# Altium schematic sheet 03-Microprocessor.SchDoc(Microprocessor)
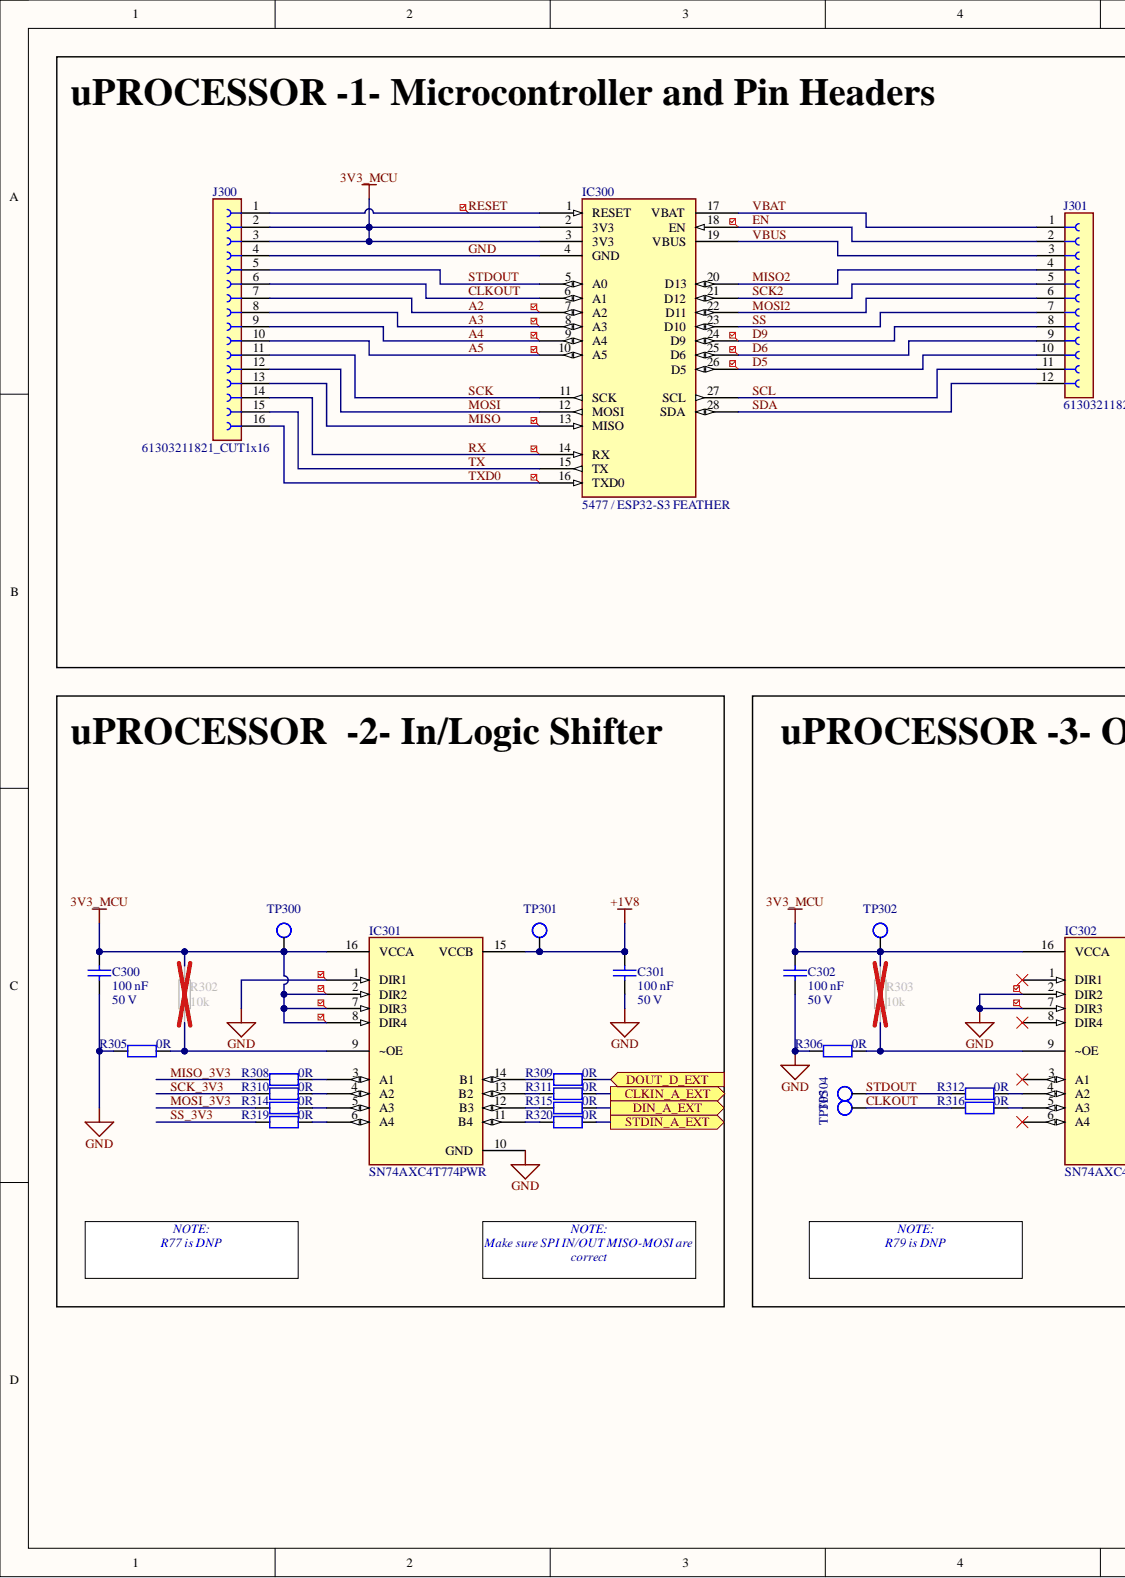
[diagram p0: 03-Microprocessor.SchDoc, left]
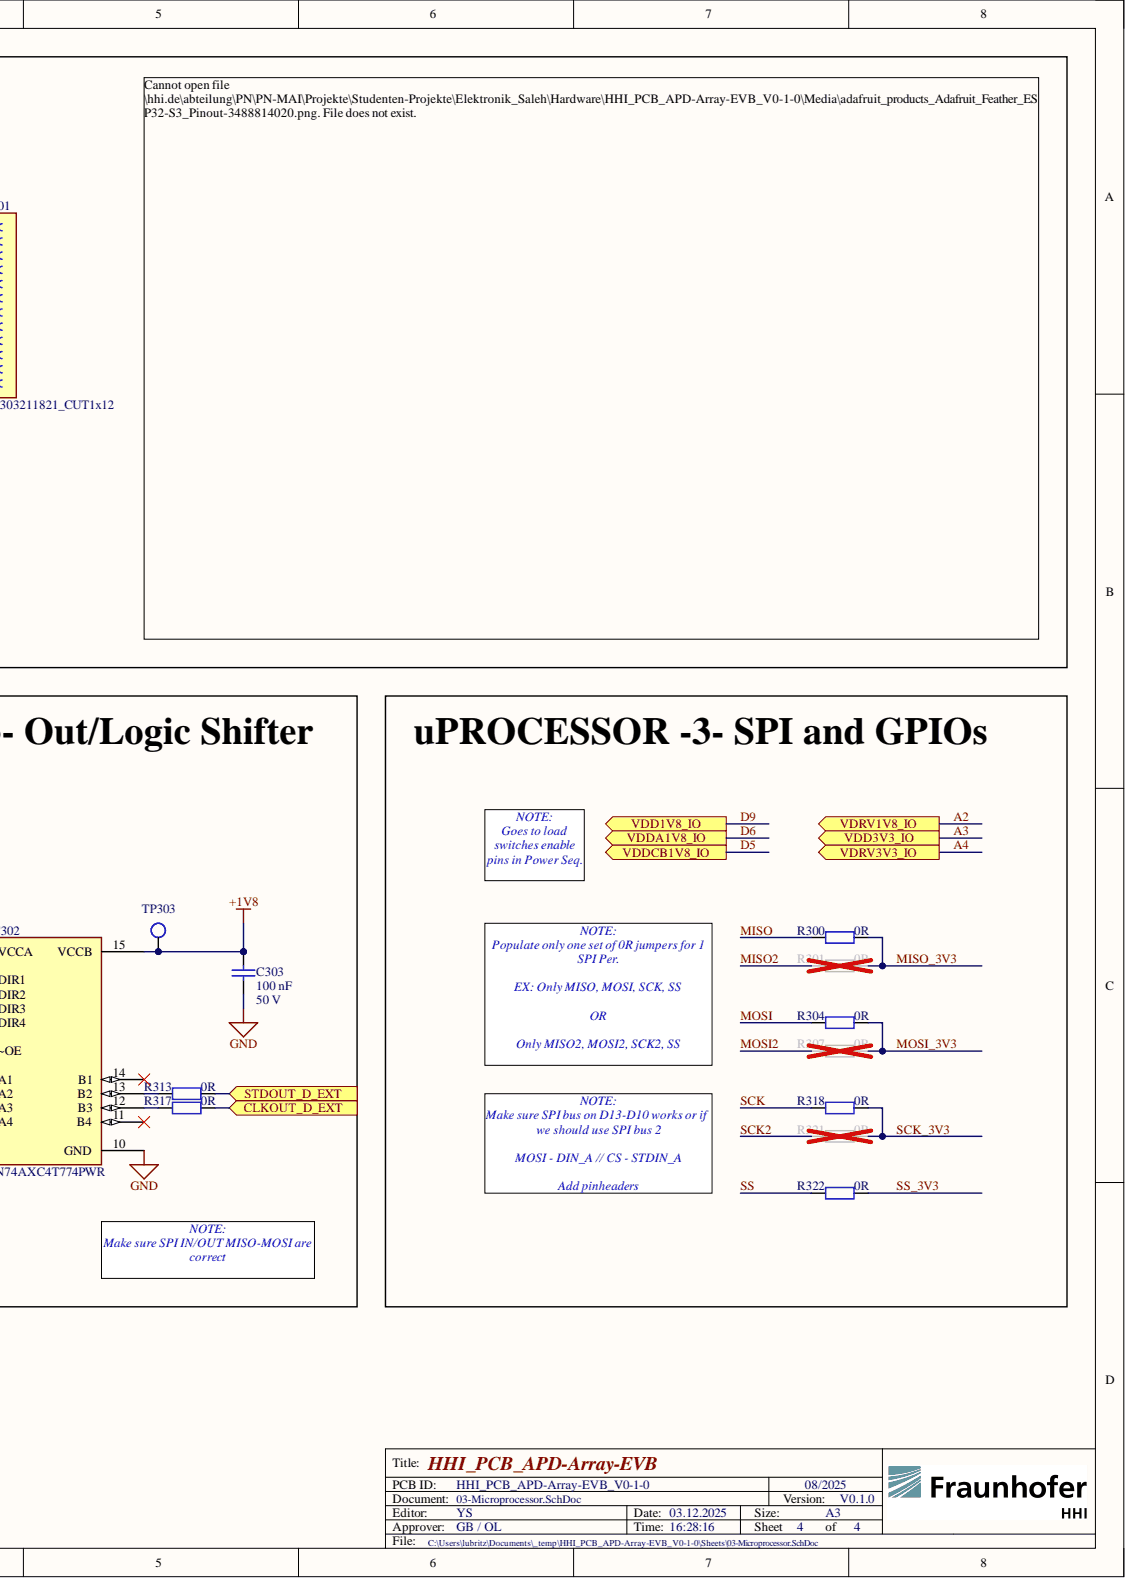
[diagram p1: 03-Microprocessor.SchDoc, right]

## Parts
C300  100 nF  Voltage: 50 V  [CAP_CAPC1608_0603_N]
C301  100 nF  Voltage: 50 V  [CAP_CAPC1608_0603_N]
C302  100 nF  Voltage: 50 V  [CAP_CAPC1608_0603_N]
C303  100 nF  Voltage: 50 V  [CAP_CAPC1608_0603_N]
IC300  5477 / ESP32-S3 FEATHER  MfrNo: 5477  [IC_ESP32-S3-FEATHER]
IC301  SN74AXC4T774PWR  [IC_TSSOP-16_TSOP65P640X120-16N]
IC302  SN74AXC4T774PWR  [IC_TSSOP-16_TSOP65P640X120-16N]
J300  61303211821_CUT1x16  [CON_FEMALE_61303211821_CUT1x16]
J301  61303211821_CUT1x12  [CON_FEMALE_61303211821_CUT1x12]
R300  0R  [RES_RESC1608_0603_N]
R301  0R  [RES_RESC1608_0603_N]
R302  10k  [RES_RESC1608_0603_N]
R303  10k  [RES_RESC1608_0603_N]
R304  0R  [RES_RESC1608_0603_N]
R305  0R  [RES_RESC1608_0603_N]
R306  0R  [RES_RESC1608_0603_N]
R307  0R  [RES_RESC1608_0603_N]
R308  0R  [RES_RESC1608_0603_N]
R309  0R  [RES_RESC1608_0603_N]
R310  0R  [RES_RESC1608_0603_N]
R311  0R  [RES_RESC1608_0603_N]
R312  0R  [RES_RESC1608_0603_N]
R313  0R  [RES_RESC1608_0603_N]
R314  0R  [RES_RESC1608_0603_N]
R315  0R  [RES_RESC1608_0603_N]
R316  0R  [RES_RESC1608_0603_N]
R317  0R  [RES_RESC1608_0603_N]
R318  0R  [RES_RESC1608_0603_N]
R319  0R  [RES_RESC1608_0603_N]
R320  0R  [RES_RESC1608_0603_N]
R321  0R  [RES_RESC1608_0603_N]
R322  0R  [RES_RESC1608_0603_N]
TP300  0,8 mm / 1,4 mm  [MISC_TP_THT_RD_0,8MM_1,4MM]  (value not drawn)  (no BOM)
TP301  0,8 mm / 1,4 mm  [MISC_TP_THT_RD_0,8MM_1,4MM]  (value not drawn)  (no BOM)
TP302  0,8 mm / 1,4 mm  [MISC_TP_THT_RD_0,8MM_1,4MM]  (value not drawn)  (no BOM)
TP303  0,8 mm / 1,4 mm  [MISC_TP_THT_RD_0,8MM_1,4MM]  (value not drawn)  (no BOM)
TP304  0,8 mm / 1,4 mm  [MISC_TP_THT_RD_0,8MM_1,4MM]  (value not drawn)  (no BOM)
TP305  0,8 mm / 1,4 mm  [MISC_TP_THT_RD_0,8MM_1,4MM]  (value not drawn)  (no BOM)

## Ports
CLKIN_A_EXT, CLKOUT_D_EXT, DIN_A_EXT, DOUT_D_EXT, STDIN_A_EXT, STDOUT_D_EXT, VDD1V8_IO, VDD3V3_IO, VDDA1V8_IO, VDDCB1V8_IO, VDRV1V8_IO, VDRV3V3_IO

## Nets
3V3_MCU: C300.1 C302.1 IC300.2(3V3) IC300.3(3V3) IC301.2(DIR2) IC301.7(DIR3) IC301.8(DIR4) IC301.16(VCCA) IC302.16(VCCA) J300.2 J300.3 R302.2 R303.2 TP300.1 TP302.1
+1V8: C301.1 C303.1 IC301.15(VCCB) IC302.15(VCCB) TP301.1 TP303.1
A2: IC300.7(A2) J300.7 ; port VDRV1V8_IO
A3: IC300.8(A3) J300.8 ; port VDD3V3_IO
A4: IC300.9(A4) J300.9 ; port VDRV3V3_IO
A5: IC300.10(A5) J300.10
CLKIN_A_EXT: R311.2 ; port CLKIN_A_EXT
CLKOUT: IC300.6(A1) J300.6 R316.1 TP305.1
CLKOUT_D_EXT: R317.2 ; port CLKOUT_D_EXT
D5: IC300.26(D5) J301.10 ; port VDDCB1V8_IO
D6: IC300.25(D6) J301.9 ; port VDDA1V8_IO
D9: IC300.24(D9) J301.8 ; port VDD1V8_IO
DIN_A_EXT: R315.2 ; port DIN_A_EXT
DOUT_D_EXT: R309.2 ; port DOUT_D_EXT
EN: IC300.18(EN) J301.2
GND: C300.2 C301.2 C302.2 C303.2 IC300.4(GND) IC301.1(DIR1) IC301.10(GND) IC302.2(DIR2) IC302.7(DIR3) IC302.10(GND) J300.4 R305.1 R306.1
MISO: IC300.13(MISO) J300.13 R300.1
MISO2: IC300.20(D13) J301.4 R301.1
MISO_3V3: R300.2 R301.2 R308.1
MOSI: IC300.12(MOSI) J300.12 R304.1
MOSI2: IC300.22(D11) J301.6 R307.1
MOSI_3V3: R304.2 R307.2 R314.1
RESET: IC300.1(RESET) J300.1
RX: IC300.14(RX) J300.14
SCK: IC300.11(SCK) J300.11 R318.1
SCK2: IC300.21(D12) J301.5 R321.1
SCK_3V3: R310.1 R318.2 R321.2
SCL: IC300.27(SCL) J301.11
SDA: IC300.28(SDA) J301.12
SS: IC300.23(D10) J301.7 R322.1
SS_3V3: R319.1 R322.2
STDIN_A_EXT: R320.2 ; port STDIN_A_EXT
STDOUT: IC300.5(A0) J300.5 R312.1 TP304.1
STDOUT_D_EXT: R313.2 ; port STDOUT_D_EXT
TX: IC300.15(TX) J300.15
TXD0: IC300.16(TXD0) J300.16
VBAT: IC300.17(VBAT) J301.1
VBUS: IC300.19(VBUS) J301.3
NetIC301_3: IC301.3(A1) R308.2
NetIC301_4: IC301.4(A2) R310.2
NetIC301_5: IC301.5(A3) R314.2
NetIC301_6: IC301.6(A4) R319.2
NetIC301_9: IC301.9(~OE) R302.1 R305.2
NetIC301_11: IC301.11(B4) R320.1
NetIC301_12: IC301.12(B3) R315.1
NetIC301_13: IC301.13(B2) R311.1
NetIC301_14: IC301.14(B1) R309.1
NetIC302_1: IC302.1(DIR1)
NetIC302_3: IC302.3(A1)
NetIC302_4: IC302.4(A2) R312.2
NetIC302_5: IC302.5(A3) R316.2
NetIC302_6: IC302.6(A4)
NetIC302_8: IC302.8(DIR4)
NetIC302_9: IC302.9(~OE) R303.1 R306.2
NetIC302_11: IC302.11(B4)
NetIC302_12: IC302.12(B3) R317.1
NetIC302_13: IC302.13(B2) R313.1
NetIC302_14: IC302.14(B1)

## Library data of the parts
C300-C303 = CAP0001: CAP / 100 nF / 0603 / 50 V / X7R / 10%; Mfr: Würth Elektronik; MfrNo: 885012206095; Database Table Name: capacitor$; Dis: Mouser; DisNo: 710-885012206095; Editor: OL; ProvidedBy: EMS; Type: MLCC; Component Kind: Standard; DesignItemID: CAP0001; Dielectric: X7R; ESR: -; Mfr-Link: https://www.we-online.com/de/components/products/WCAP-CSGP-50VDC; Package: 0603; Pins: 2; Status: Done; TempHigh: 125 °C; TempLow: -55 °C; Tolerance: 10%; Value: 100 nF; Library Name: Altium-Lib-HHI.DbLib
IC300 = IC0021: IC / SoC / 5477 / ESP32-S3 FEATHER / 4MB/2MB PSRAM; Mfr: Adafruit Industries; Database Table Name: ic$; Dis: Digikey; DisNo: 1528-5477-ND; Editor: OL; ProvidedBy: HHI; Supply Voltage: 3,3 V / 5 V; AdditionalDescription: 4MB/2MB PSRAM; Component Kind: Standard; DesignItemID: IC0021; Frequency: -; InputVoltage: -; Library Name: Altium-Lib-HHI.DbLib; MaxCurrent: -; Memory: -; Mfr-Link: https://www.adafruit.com/product/5477; OutputVoltage: -; Package: DevKit; Pins: 28; SensorType: -; Status: Done; TempHigh: -; TempLow: -; Type: SoC; Value: 5477 / ESP32-S3 FEATHER
IC301 IC302 = IC0022: IC / logic / SN74AXC4T774PWR / Bi-Directional Logic Level Shifter; Mfr: Texas Instruments; Database Table Name: ic$; Dis: Mouser; DisNo: 595-SN74AXC4T774PWR; Editor: OL; Frequency: 310 Mbps; MaxCurrent: 100 mA; ProvidedBy: EMS; Supply Voltage: 0,65V / 3,6V; AdditionalDescription: Bi-Directional Logic Level Shifter; Component Kind: Standard; DesignItemID: IC0022; InputVoltage: -; Memory: -; Mfr-Link: https://www.ti.com/product/SN74AXC4T774?keyMatch=SN74AXC4T774&tisearch=universal_search&usecase=GPN-ALT; MfrNo: SN74AXC4T774PWR; OutputVoltage: -; Package: TSSOP-16; Pins: 16; SensorType: -; Status: Done; TempHigh: 125  °C; TempLow: -40  °C; Type: logic; Value: SN74AXC4T774PWR; Library Name: Altium-Lib-HHI.DbLib
J300 = CON0027: CON / 1 x 16 / female / Socket Header / 61303211821_CUT1x16; Mfr: Würth Elektronik; Assembly: THT; Current: 3 A; Database Table Name: connectors$; Dis: Mouser; DisNo: 710-61303211821; Editor: OL; Pins per Row: 16; Pitch: 2,54 mm; Plating: Gold; ProvidedBy: HHI; Rows: 1; Voltage: 250 V; Component Kind: Standard; DesignItemID: CON0027; Gender: female; Library Name: Altium-Lib-HHI.DbLib; Mfr-Link: https://www.we-online.com/de/components/products/PHD_2_54_SOCKET_HEADER_6130XX11821; MfrNo: 61303211821_CUT1x16; Pins: 16; Status: Done; TempHigh: 105 °C; TempLow: -40 °C; Type: Socket Header; Value: 61303211821_CUT1x16
J301 = CON0026: CON / 1 x 12 / female / Socket Header / 61303211821_CUT1x12; Mfr: Würth Elektronik; Assembly: THT; Current: 3 A; Database Table Name: connectors$; Dis: Mouser; DisNo: 710-61303211821; Editor: OL; Pins per Row: 12; Pitch: 2,54 mm; Plating: Gold; ProvidedBy: HHI; Rows: 1; Voltage: 250 V; Component Kind: Standard; DesignItemID: CON0026; Gender: female; Library Name: Altium-Lib-HHI.DbLib; Mfr-Link: https://www.we-online.com/de/components/products/PHD_2_54_SOCKET_HEADER_6130XX11821; MfrNo: 61303211821_CUT1x12; Pins: 12; Status: Done; TempHigh: 105 °C; TempLow: -40 °C; Type: Socket Header; Value: 61303211821_CUT1x12
R300 R301 R304-R322 = RES0006: RES / 0R / 0603 / 5% / 75 V / 100 mW; Mfr: YAGEO; MfrNo: RC0603JR-070RL; Database Table Name: resistor$; Dis: Mouser; DisNo: 603-RC0603JR-070RL; Editor: OL; Power: 100 mW; ProvidedBy: EMS; Voltage: 75 V; Component Kind: Standard; DesignItemID: RES0006; Mfr-Link: https://www.yageo.com/upload/media/product/productsearch/datasheet/rchip/PYu-RC_Group_51_RoHS_L_12.pdf; Package: 0603; Pins: 2; Status: Done; TempHigh: 155 °C; TempLow: -55 °C; Tolerance: 5%; Type: jumper; Value: 0R; Library Name: Altium-Lib-HHI.DbLib
R302 R303 = RES0011: RES / 10k / 0603 / 1% / 75 V / 100 mW; Mfr: YAGEO; MfrNo: RC0603FR-0710KL; Database Table Name: resistor$; Dis: Mouser; DisNo: 603-RC0603FR-0710KL; Editor: OL; Power: 100 mW; ProvidedBy: EMS; Voltage: 75 V; Component Kind: Standard; DesignItemID: RES0011; Mfr-Link: https://www.yageo.com/upload/media/product/productsearch/datasheet/rchip/PYu-RC_Group_51_RoHS_L_12.pdf; Package: 0603; Pins: 2; Status: Done; TempHigh: 155 °C; TempLow: -55 °C; Tolerance: 1%; Type: resistor; Value: 10k; Library Name: Altium-Lib-HHI.DbLib
TP300-TP305 = MISC0008: MISC / test-point / 0,8 mm / 1,4 mm / round / THT; Database Table Name: misc$; Editor: OL; AdditionalDescription: round / THT; DesignItemID: MISC0008; Dis: -; DisNo: -; Frequency: -; MaxCurrent: -; Mfr: -; Mfr-Link: -; MfrNo: -; Package: -; Pins: -; ProvidedBy: -; Status: Done; TempHigh: -; TempLow: -; Type: test-point; Value: 0,8 mm / 1,4 mm; Voltage: -; Library Name: Altium-Lib-HHI.DbLib
